# Altium schematic sheet 05 外设部分.SchDoc("05 外设部分")
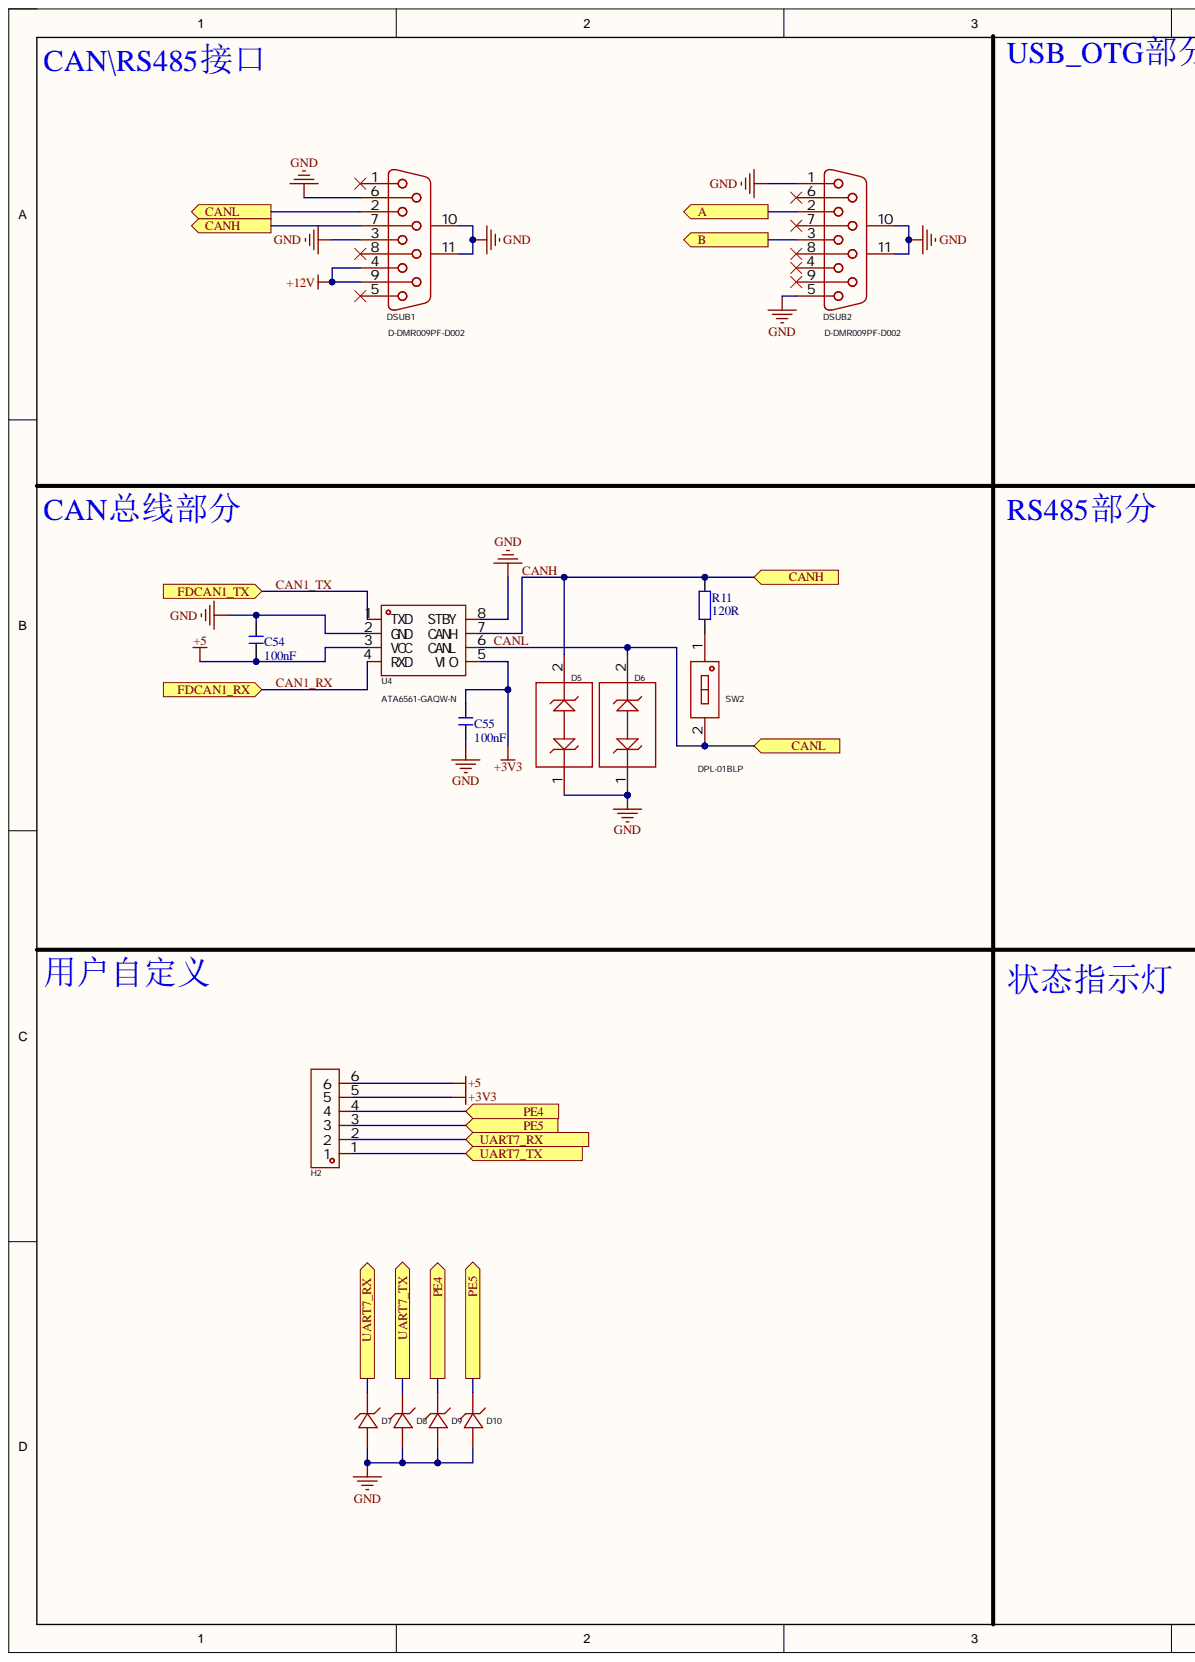
[diagram p0: 05 外设部分.SchDoc, left]
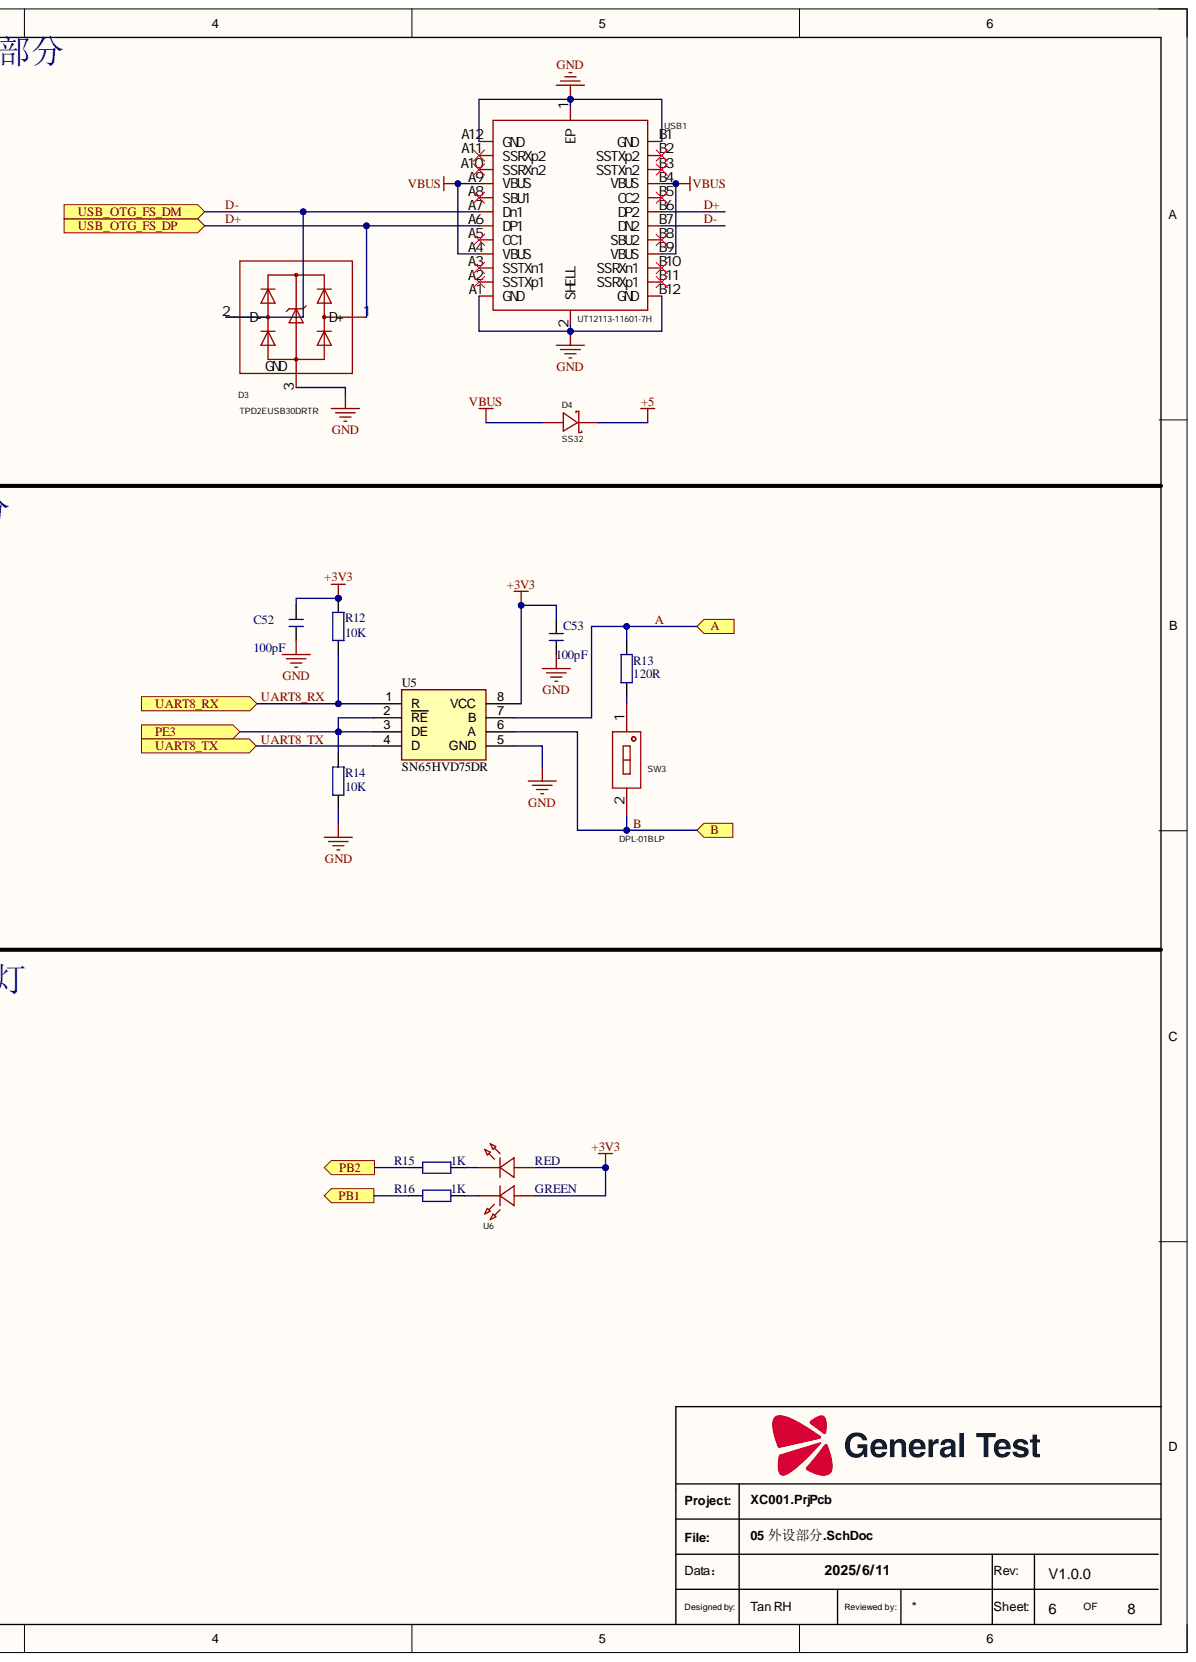
[diagram p1: 05 外设部分.SchDoc, right]

## Parts
C52  100pF  [C0603_L]
C53  100pF  [C0603_L]
C54  100nF  [C0603_L]
C55  100nF  [C0402_L]
D3  TPD2EUSB30DRTR  [SOT-9X3-3_L1.0-W0.8-P0.35-LS1.0-BR]
D4  SS32  [SMA_L4.3-W2.6-LS5.2-RD]
D5  TPD1E10B06DPYR  [X1SON-2_L1.0-W0.6-P0.65-BI]  (value not drawn)
D6  TPD1E10B06DPYR  [X1SON-2_L1.0-W0.6-P0.65-BI]  (value not drawn)
D7  ESD321DPYR  [X1SON-2_L1.0-W0.6-P0.65-RD]  (value not drawn)
D8  ESD321DPYR  [X1SON-2_L1.0-W0.6-P0.65-RD]  (value not drawn)
D9  ESD321DPYR  [X1SON-2_L1.0-W0.6-P0.65-RD]  (value not drawn)
D10  ESD321DPYR  [X1SON-2_L1.0-W0.6-P0.65-RD]  (value not drawn)
DSUB1  D-DMR009PF-D002  [DSUB-TH_DMR-9S]
DSUB2  D-DMR009PF-D002  [DSUB-TH_DMR-9S]
H2  PZ254V-11-06P  [HDR-TH_6P-P2.54-V-M]  (value not drawn)
R11  120R  [R0402_L]
R12  10K  [R0402_L]
R13  120R  [R0402_L]
R14  10K  [R0402_L]
R15  1K  [R0402_L]
R16  1K  [R0402_L]
SW2  DPL-01BLP  [SW-TH_2P-L4.0-W10.8-P7.62]
SW3  DPL-01BLP  [SW-TH_2P-L4.0-W10.8-P7.62]
U4  ATA6561-GAQW-N  [SOIC-8_L4.9-W3.9-P1.27-LS6.0-BL-2]
U5  SN65HVD75DR  [SOIC127P600X175-8N]
U6  A-SP1102AR6GHC-A01-2C  [LED-SMD_4P-L3.0-W1.5_A-SP1102AR6GHC-A01-2C]  (value not drawn)
USB1  UT12113-11601-7H  [USB-C-SMD_UT12113-11601-7H]

## Ports
A, B, CANH, CANL, FDCAN1_RX, FDCAN1_TX, PB1, PB2, PE3, PE4, PE5, UART7_RX, UART7_TX, UART8_RX, UART8_TX, USB_OTG_FS_DM, USB_OTG_FS_DP

## Nets
+3V3: C52.2 C53.2 C55.2 H2.5 R12.2 U4.5(VIO) U5.8(VCC) U6.1 U6.2
+5: C54.1 D4.1 H2.6 U4.3(VCC)
+12V: DSUB1.4 DSUB1.9
GND: C52.1 C53.1 C54.2 C55.1 D3.3 D5.1 D6.1 D7.2 D8.2 D9.2 D10.2 DSUB1.3 DSUB1.6 DSUB1.10 DSUB1.11 DSUB2.1 DSUB2.5 DSUB2.10 DSUB2.11 R14.1 U4.2(GND) U4.8(STBY) U5.5(GND) USB1.1(EP) USB1.2(SHELL) USB1.A1(GND) USB1.A12(GND) USB1.B1(GND) USB1.B12(GND)
VBUS: D4.2 USB1.A4(VBUS) USB1.A9(VBUS) USB1.B4(VBUS) USB1.B9(VBUS)
A: DSUB2.2 R13.2 U5.7(B) ; port A
B: DSUB2.3 SW3.2 U5.6(A) ; port B
CAN1_RX: U4.4(RXD) ; port FDCAN1_RX
CAN1_TX: U4.1(TXD) ; port FDCAN1_TX
CANH: D5.2 DSUB1.7 R11.1 U4.7(CANH) ; port CANH
CANL: D6.2 DSUB1.2 SW2.2 U4.6(CANL) ; port CANL
D+: D3.1 USB1.A6(DP1) USB1.B6(DP2) ; port USB_OTG_FS_DP
D-: D3.2 USB1.A7(Dn1) USB1.B7(DN2) ; port USB_OTG_FS_DM
PB1: R16.1 ; port PB1
PB2: R15.1 ; port PB2
PE3: R14.2 U5.2(RE) U5.3(DE) ; port PE3
PE4: D9.1 H2.4 ; port PE4
PE5: D10.1 H2.3 ; port PE5
UART7_RX: D7.1 H2.2 ; port UART7_RX
UART7_TX: D8.1 H2.1 ; port UART7_TX
UART8_RX: R12.1 U5.1(R) ; port UART8_RX
UART8_TX: U5.4(D) ; port UART8_TX
NetR11_2: R11.2 SW2.1
NetR13_1: R13.1 SW3.1
NetR15_2: R15.2 U6.4
NetR16_2: R16.2 U6.3

Not connected: DSUB1 1 5 8; DSUB2 4 6 7 8 9; USB1 A2(SSTXp1) A3(SSTXn1) A5(CC1) A8(SBU1) A10(SSRXn2) A11(SSRXp2) B2(SSTXp2) B3(SSTXn2) B5(CC2) B8(SBU2) B10(SSRXn1) B11(SSRXp1)

## Library data of the parts
C52-C55 = C: 贴片电容; BOM名称: 无极性贴片电容; 淘宝ID: 封装库来自淘宝 ID：黄晶宝石; Component Kind: Standard; Library Name: 0.1 - 电阻-电容-电感.SchLib; 唯一出售店铺网址: https://shop463601444.taobao.com; 联系售后，技术支持: https://shop463601444.taobao.com
D3 = TPD2EUSB30DRTR: Manufacturer: TI(德州仪器); Add into BOM: yes; Breakdown Voltage: 7V; Maximum Clamping Voltage: 8V; Peak Pulse Current (Ipp): 1A; Peak Pulse Power Dissipation (Ppp): 45W; polarity: 单向; Reverse Stand-Off Voltage (Vrwm): 5.5V; Type: ESD; Component Kind: Standard; Convert to PCB: yes; Datasheet: https://www.ti.com/cn/lit/gpn/tpd2eusb30; Device: TPD2EUSB30DRTR; JLCPCB Part Class: Extended Part; LCSC Part Name: 单向ESD; Library Name: RK3562 Pcb.SchLib; Manufacturer Part: TPD2EUSB30DRTR; Operating Temperature: -40℃~+85℃@(Ta); PCB3D: SOT-9X3-3_L1.0-W0.8-H0.5-LD1.0-P0.35; Supplier: LCSC; Supplier Footprint: SOT(9x3); Supplier Part: C97502; Symbol: TPD2EUSB30DRTR
D4 = SS32_C34897: Manufacturer: MDD(辰达半导体); Add into BOM: yes; Diode Configuration: 独立式; Forward Voltage (Vf@If): 550mV@3A; Rectified Current: 3A; Reverse Leakage Current (Ir): 500uA@20V; Reverse Voltage (Vr): 20V; Component Kind: Standard; Convert to PCB: yes; Datasheet: https://atta.szlcsc.com/upload/public/pdf/source/20240710/2118BB86FDDB2E0B294389AC1F3F65C8.pdf; Device: SS32_C34897; JLCPCB Part Class: Extended Part; LCSC Part Name: 电压:20V 电流:3A; Library Name: Schlib1.SchLib; Manufacturer Part: SS32; Supplier: LCSC; Supplier Footprint: SMA(DO-214AC); Supplier Part: C34897; Symbol: SS32_C34897
D5 D6 = TPD1E10B06DPYR: Manufacturer: TI(德州仪器); Add into BOM: yes; Clamping Voltage: 14V; Peak Pulse Power Dissipation (Ppp): 90W; Reverse Stand-Off Voltage (Vrwm): 5.5V; Type: TVS; Voltage - Breakdown: 6V; Component Kind: Standard; Convert to PCB: yes; Datasheet: https://www.ti.com/lit/ds/symlink/tpd1e10b06.pdf; Device: TPD1E10B06DPYR; JLCPCB Part Class: Extended Part; LCSC Part Name: TPD1E10B06DPYR; Library Name: RK3562 Pcb.SchLib; Manufacturer Part: TPD1E10B06DPYR; PCB3D: X1SON-2_L1.0-W0.6-H0.4-P0.65; Peak Pulse Current (Ipp): -; Supplier: LCSC; Supplier Footprint: X1-SON-2(0.6x1); Supplier Part: C48260; Symbol: TPD1E10B06DPYR
D7-D10 = ESD321DPYR_C2868952: Manufacturer: TI(德州仪器); Add into BOM: yes; Breakdown Voltage: 4.5V; Maximum Clamping Voltage: 6.8V; Peak Pulse Current (Ipp)@10/1000us: 6A@8/20us; Peak Pulse Power Dissipation (Ppp)@10/1000us: 40W; polarity: 单向; Reverse Stand-Off Voltage (Vrwm): 3.6V@Max; Type: TVS; Component Kind: Standard; Convert to PCB: yes; Datasheet: https://www.ti.com/cn/lit/gpn/esd321; Device: ESD321DPYR_C2868952; JLCPCB Part Class: Extended Part; LCSC Part Name: ESD321DPYR; Library Name: Schlib1.SchLib; Manufacturer Part: ESD321DPYR; Supplier: LCSC; Supplier Footprint: X1-SON-2(0.6x1); Supplier Part: C2868952; Symbol: ESD321DPYR_C2868952
DSUB1 DSUB2 = D-DMR009PF-D002: Manufacturer: Ckmtw(灿科盟); Add into BOM: yes; Connector Type: 常规D-Sub; Current Rating-Signal (Max): 3A; Gender: 母; Symbol: DMR-9S; Colour: 黑色; Component Kind: Standard; Convert to PCB: yes; Current Rating-Power (Max): -; Datasheet: https://atta.szlcsc.com/upload/public/pdf/source/20171123/C141882_15114109686951137625.pdf; Device: D-DMR009PF-D002; JLCPCB Part Class: Extended Part; LCSC Part Name: D-Sub 母 P数:9P; Library Name: RK3562 Pcb.SchLib; Manufacturer Part: D-DMR009PF-D002; Mounting Style: 弯插; Number of Pins: 9P; Number of Rows: 2; Operating Temperature Range: -50℃~+105℃; PCB3D: DSUB-TH_DMR-9S; Rated Voltage (Max): -; Supplier: LCSC; Supplier Footprint: 弯插; Supplier Part: C141882
H2 = PZ254V-11-06P: Manufacturer: XFCN(兴飞); Add into BOM: yes; Circluar Pins/Square Pins: 方针; Pin Structure: 1x6P; Pitch: 2.54mm; Color: 黑色; Component Kind: Standard; Contact Material: 黄铜; Contact Plating: -; Convert to PCB: yes; Current Rating (Max): -; Datasheet: https://atta.szlcsc.com/upload/public/pdf/source/20200309/C492405_597F1930F5B55CC3C7FD95458F1C3977.pdf; Device: PZ254V-11-06P; Insulation Height: 2.5mm; JLCPCB Part Class: Extended Part; LCSC Part Name: 间距:2.54mm 1x6P 直插 方针; Length of End Connection Pin: 3mm; Length of Mating Pin: 6mm; Library Name: RK3562 Pcb.SchLib; Manufacturer Part: PZ254V-11-06P; Mounting Type: 直插; Number of Pins: 6P; Number of Rows: 单排; Operating Temperature Range: -40℃~+105℃; PCB3D: HDR-TH_6P-P2.54-M-LI-1X6P; Row Spacing: -; Supplier: LCSC; Supplier Footprint: 插件,P=2.54mm; Supplier Part: C492405; Symbol: PZ254V-11-06P; Voltage Rating (Max): -
R11-R16 = R: 贴片电阻; 封装库来自淘宝卖家 ID：黄晶宝石: 其他店铺购入均为盗版，不享受售后技术支持服务，请立即申请退款; 版权归属: 来自淘宝 ID：黄晶宝石; BOM名称: 贴片电阻; Component Kind: Standard; Library Name: 0.1 - 电阻-电容-电感.SchLib; 联系售后: https://item.taobao.com/item.htm?id=566775463719
SW2 SW3 = DPL-01BLP: Manufacturer: XKB Connectivity(中国星坤); Add into BOM: yes; Circuit: 单刀单掷; Current Rating: 25mA; Number of Positions: 1位; Operating Life: 3千次; Pin Style: PC引脚; Voltage Rating: 24V; Color: 蓝色; Component Kind: Standard; Convert to PCB: yes; Datasheet: https://atta.szlcsc.com/upload/public/pdf/source/20211009/01DEE1ECABD722E01D26E80670303730.pdf; Device: DPL-01BLP; JLCPCB Part Class: Extended Part; LCSC Part Name: 琴键 1位拨码开关; Lead Pitch: -; Library Name: RK3562 Pcb.SchLib; Manufacturer Part: DPL-01BLP; Operating Temperature: -20℃~+70℃; PCB3D: SW-TH_DLP-01BLP-L4.0-W12.6-H10.0-P2.54-LS7.6; Supplier: LCSC; Supplier Footprint: 插件; Supplier Part: C692544; Symbol: DPL-01BLP; Type: 琴键
U4 = ATA6561-GAQW-N: Manufacturer: MICROCHIP(美国微芯); Add into BOM: yes; Data Rate: 5Mbps; Supply Voltage: 4.5V~5.5V; Symbol: ATA6561-GAQW-N_C616016; Component Kind: Standard; Convert to PCB: yes; Datasheet: https://atta.szlcsc.com/upload/public/pdf/source/20211008/C2671262_D7FCCB811013C7D69A57B5A2D230BAD0.pdf; Device: ATA6561-GAQW-N; JLCPCB Part Class: Extended Part; LCSC Part Name: 高速CAN收发器，具有待机模式; Library Name: RK3562 Pcb.SchLib; Manufacturer Part: ATA6561-GAQW-N; Operating Temperature: -40℃~+150℃; PCB3D: SOIC-8_L4.9-W3.9-H1.7-LS6.0-P1.27; Supplier: LCSC; Supplier Footprint: SOIC-8; Supplier Part: C616016; Type: CAN收发器
U5 = SN65HVD75DR: Integrated Circuit; Manufacturer_Name: Texas Instruments; Arrow Part Number: SN65HVD75DR; Arrow Price/Stock: https://www.arrow.com/en/products/sn65hvd75dr/texas-instruments?utm_currency=USD&region=nac; Component Kind: Standard; Datasheet Link: http://www.ti.com/lit/gpn/sn65hvd75; Height: 1.75mm; Manufacturer_Part_Number: SN65HVD75DR; Mouser Part Number: 595-SN65HVD75DR; Mouser Price/Stock: https://www.mouser.co.uk/ProductDetail/Texas-Instruments/SN65HVD75DR?qs=X2y4EmDJZOW1Viw5bHgBbQ%3D%3D
U6 = A-SP1102AR6GHC-A01-2C: Manufacturer: Amicc(欧密格光电); Add into BOM: yes; Dominant Wavelength: 515nm~530nm；622nm; Emitted Color: 红色,翠绿色; Forward Current: 20mA; Forward Voltage (VF): 3.3V; Lens Color: 无色透明透镜; Luminous Intensity: 285mcd; Mounting Sytle: 侧贴; Peak Wavelength: 520nm；632nm; Power Dissipation: 60mW；90mW; Viewing Angle: 120°; Color Temperature: -; Component Kind: Standard; Convert to PCB: yes; Datasheet: https://atta.szlcsc.com/upload/public/pdf/source/20220916/BB3AE68E3F2CCED0BF18D5DAD5489B6F.pdf; Device: A-SP1102AR6GHC-A01-2C; Diode Configuration: -; JLCPCB Part Class: Extended Part; Lamp Holder Type: -; LCSC Part Name: A-SP1102AR6GHC-A01-2C; Library Name: RK3562 Pcb.SchLib; Manufacturer Part: A-SP1102AR6GHC-A01-2C; Operating Temperature: -40℃~+85℃; PCB3D: LED-SMD_4P-L3.0-W1.5_A-SP1102AR6GHC-A01-2C; Supplier: LCSC; Supplier Footprint: SMD-4P,1.5x3mm; Supplier Part: C5160724; Symbol: A-SP1102AR6GHC-A01-2C
USB1 = UT12113-11601-7H: Manufacturer: FOXCONN(富士康); Add into BOM: yes; Connector Type: Type-C; Gender: 母; Number of Contacts: 24P; Number of Ports: 1; Component Kind: Standard; Convert to PCB: yes; Current Rating - Power (Max): -; Datasheet: https://atta.szlcsc.com/upload/public/pdf/source/20210319/C2761409_FDA15447996546664E026DE8C7BF71B6.pdf; Device: UT12113-11601-7H; JLCPCB Part Class: Extended Part; LCSC Part Name: Type-C 母 卧贴; Library Name: RK3562 Pcb.SchLib; Manufacturer Part: UT12113-11601-7H; Mounting Style: 卧贴; Operating Temperature Range: -55℃~+85℃; PCB3D: USB-C-SMD_UT12113-11601-7H; Standard: -; Supplier: LCSC; Supplier Footprint: SMD; Supplier Part: C2761409; Symbol: UT12113-11601-7H
